# Altium schematic sheet 超级电容3.SchDoc
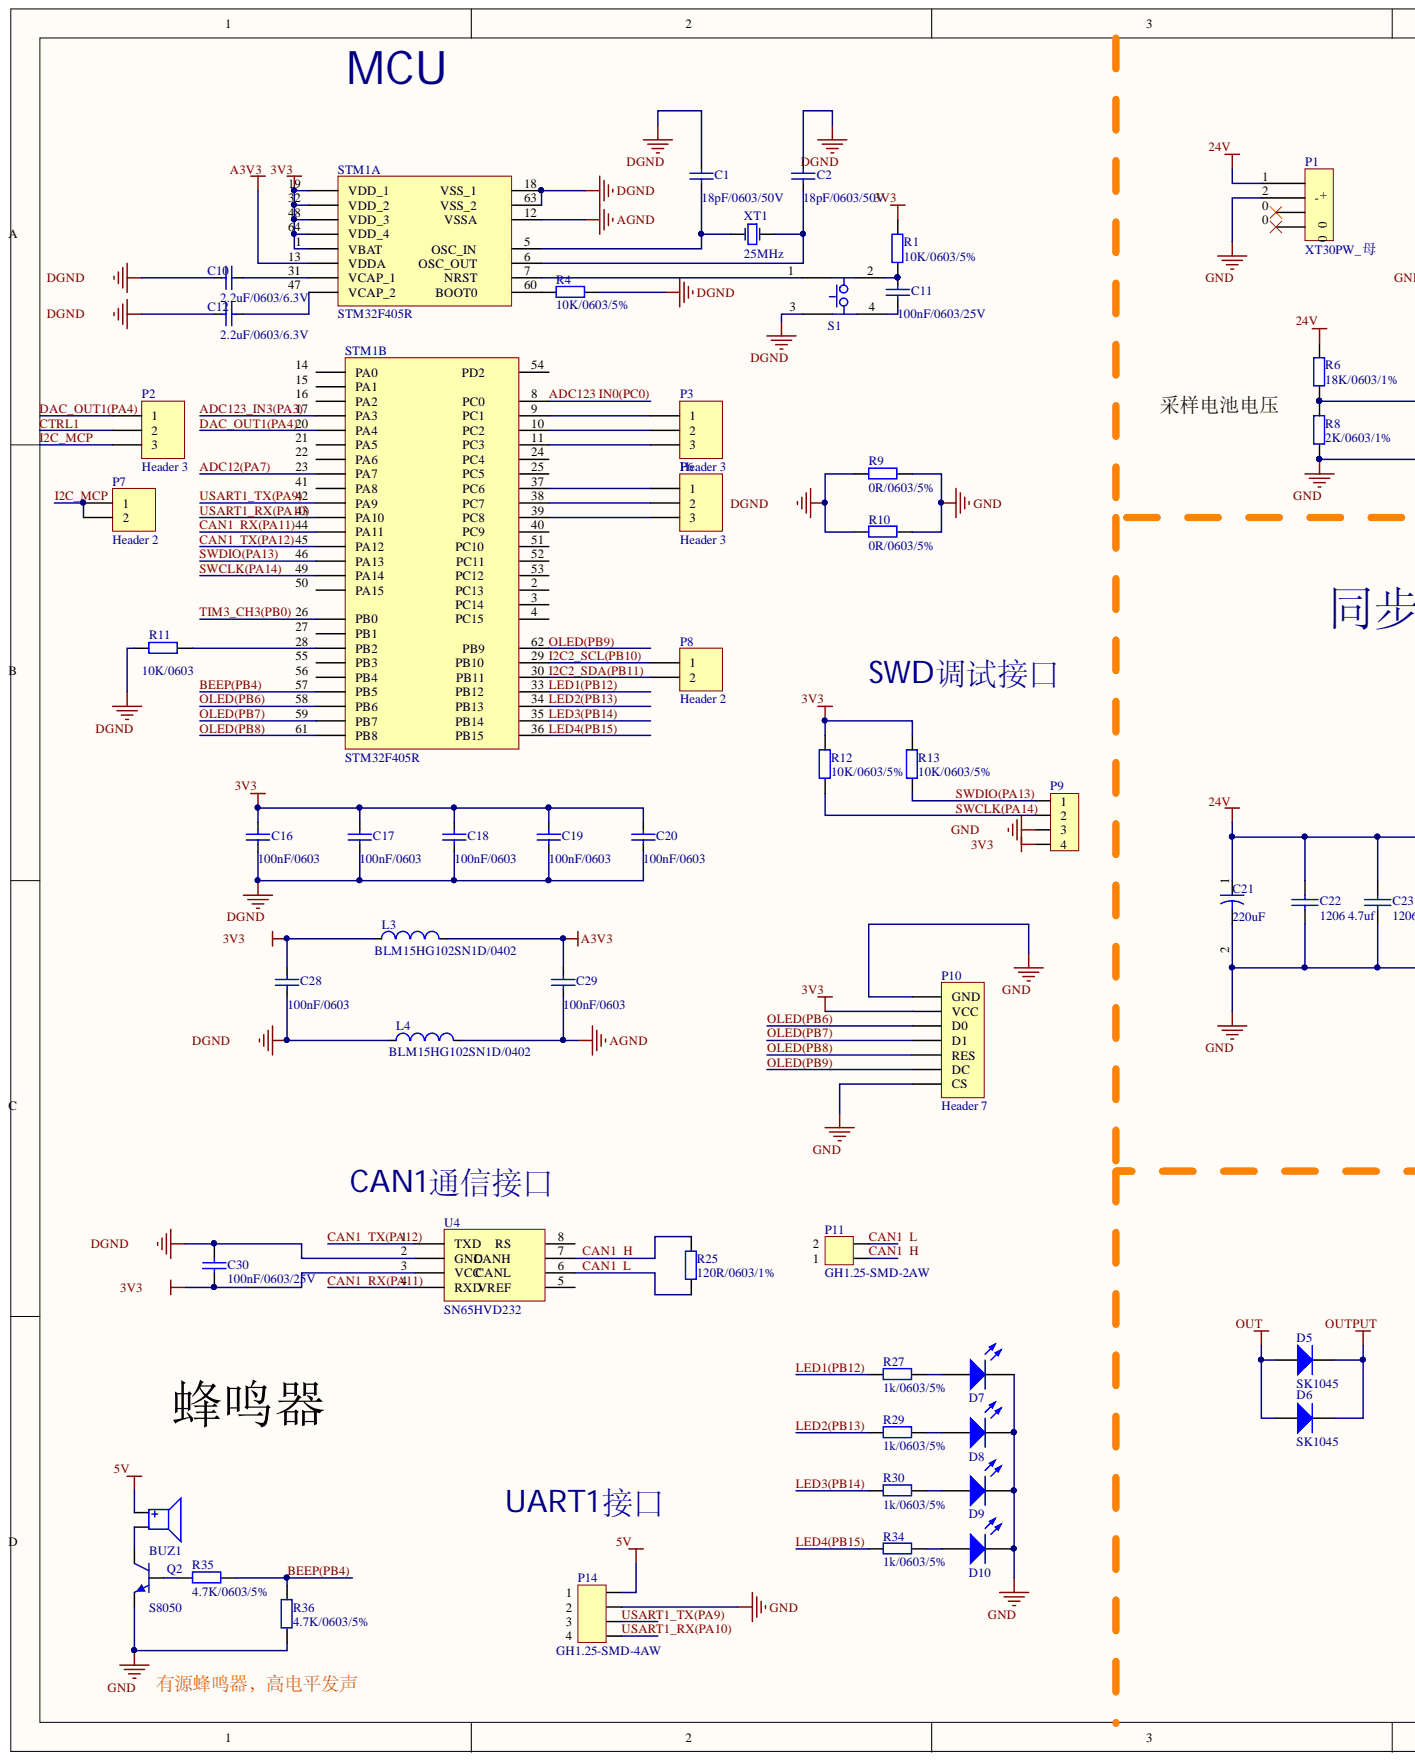
[diagram p0: 超级电容3.SchDoc, left]
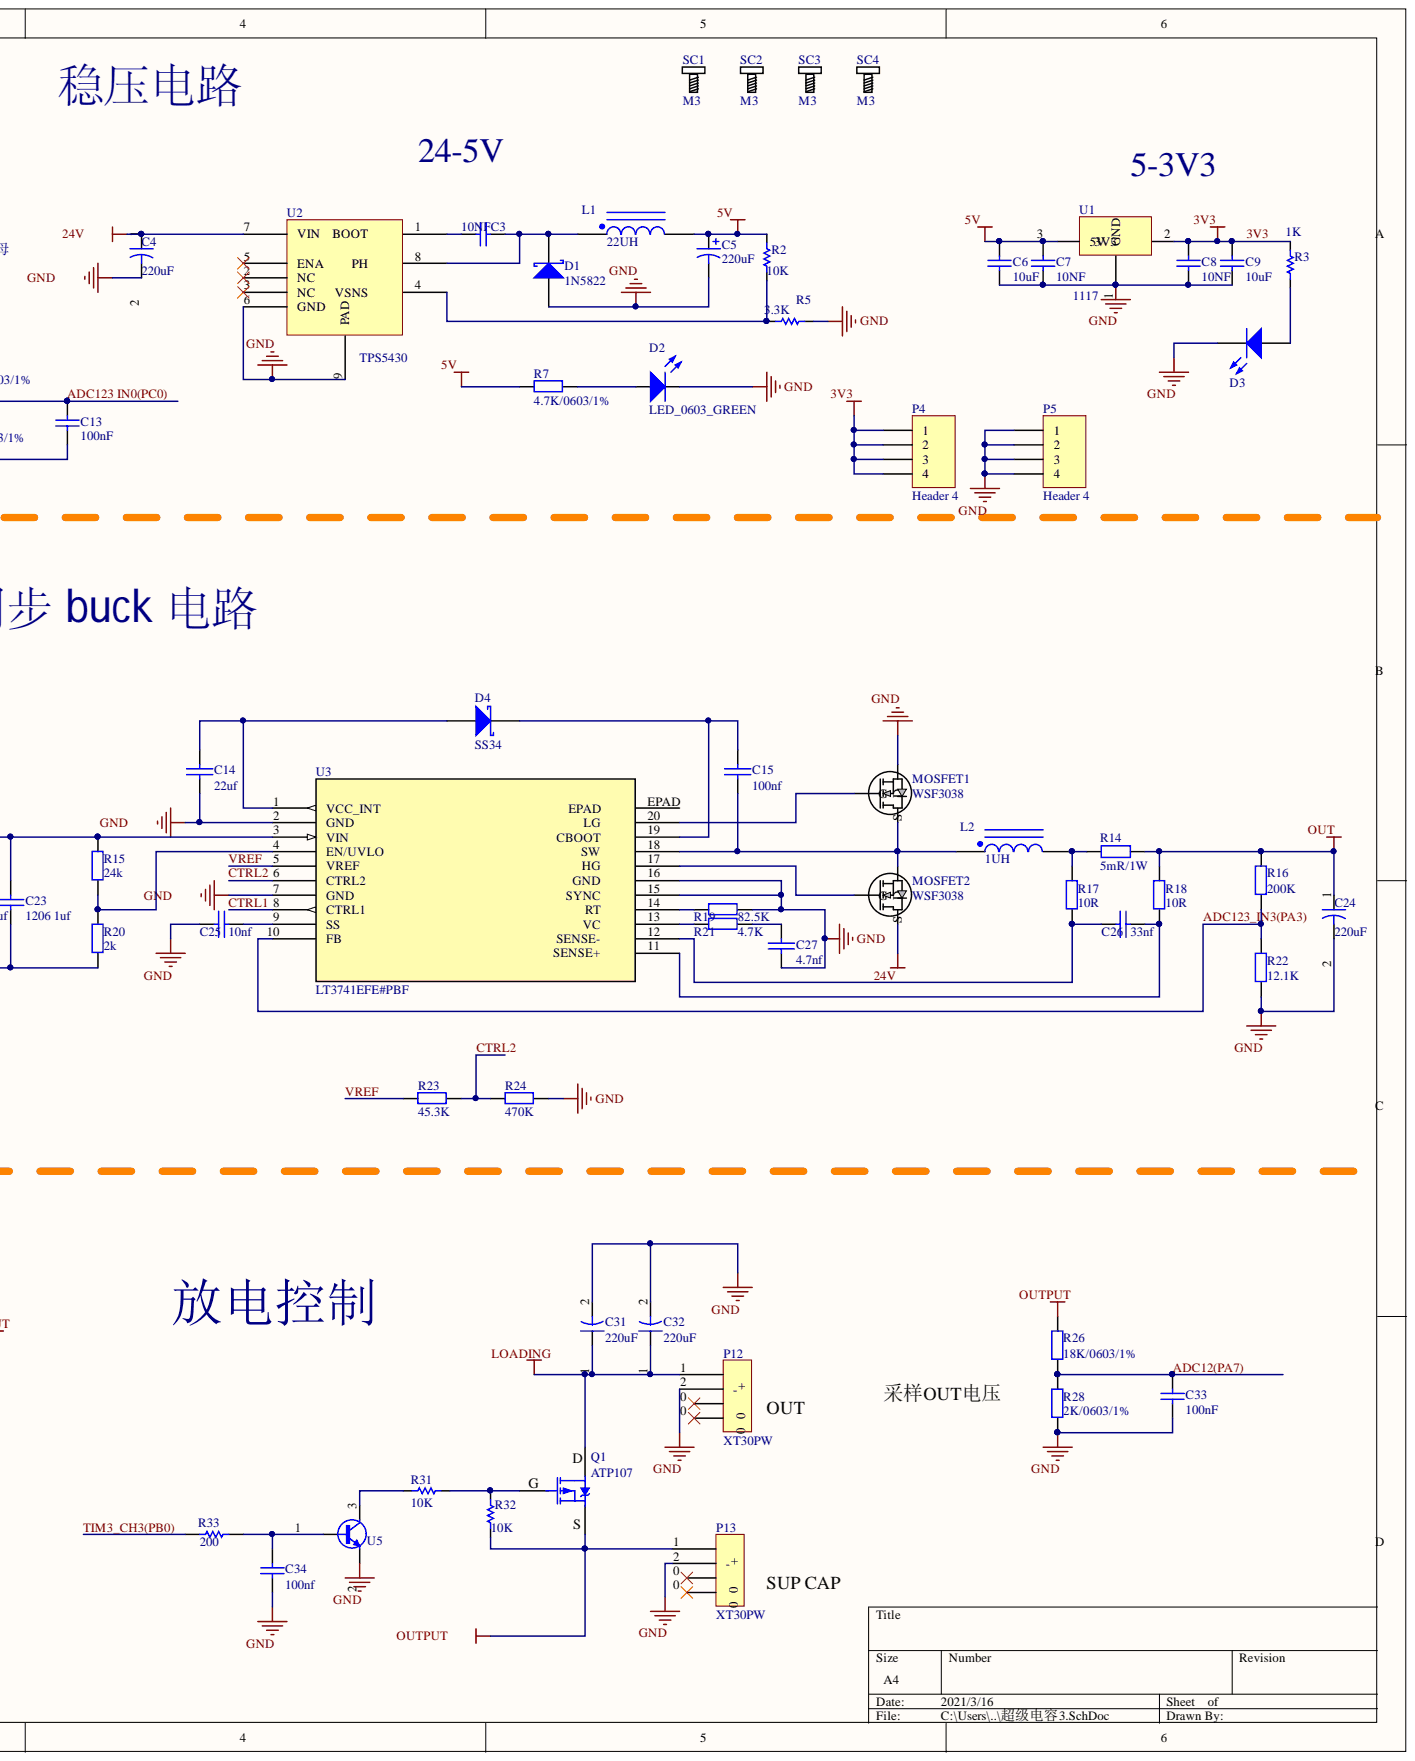
[diagram p1: 超级电容3.SchDoc, right]

## Parts
BUZ1  Buzzer  [蜂鸣器]  (value not drawn)
C1  value 18pF/0603/50V  [C-SMD-0603]  (hidden comment: 18PF)
C2  value 18pF/0603/50V  [C-SMD-0603]  (hidden comment: 18PF)
C3  10NF  [C-SMD-0603]
C4  220uF  [c8*12]
C5  220uF  [TCAP7343]
C6  10uF  [C-SMD-0603]
C7  10NF  [C-SMD-0603]
C8  10NF  [C-SMD-0603]
C9  10uF  [C-SMD-0603]
C10  value 2.2uF/0603/6.3V  [C-SMD-0603]  (hidden comment: 2.2UF)
C11  value 100nF/0603/25V  [C-SMD-0603]  (hidden comment: 100NF)
C12  value 2.2uF/0603/6.3V  [C-SMD-0603]  (hidden comment: 2.2UF)
C13  100NF  [C-SMD-0603]
C14  22uf  [C-SMD-0603]
C15  100nf  [C-SMD-0603]
C16  100nF/0603  [C-SMD-0603]
C17  100nF/0603  [C-SMD-0603]
C18  100nF/0603  [C-SMD-0603]
C19  100nF/0603  [C-SMD-0603]
C20  100nF/0603  [C-SMD-0603]
C21  220uF  [c8*12]
C22  1206 4.7uf  [C 1206]
C23  1206 1uf  [C 1206]
C24  220uF  [c8*12]
C25  10nf  [C-SMD-0603]
C26  33nf  [C-SMD-0603]
C27  4.7nf  [C-SMD-0603]
C28  100nF/0603  [C-SMD-0603]
C29  100nF/0603  [C-SMD-0603]
C30  value 100nF/0603/25V  [C-SMD-0603]  (hidden comment: 100NF)
C31  220uF  [c8*12]
C32  220uF  [c8*12]
C33  100NF  [C-SMD-0603]
C34  100nf  [C-SMD-0603]
D1  1N5822  [DO-214AC_SS14]
D2  LED_0603_GREEN  [LED_0603_GREEN]
D3  LED0  [LED_0603_GREEN]  (value not drawn)
D4  SS34  [DO-214AC_SS14]
D5  SK1045  [SMC]
D6  SK1045  [SMC]
D7  LED  [LED-0603]  (value not drawn)
D8  LED  [LED-0603]  (value not drawn)
D9  LED  [LED-0603]  (value not drawn)
D10  LED  [LED-0603]  (value not drawn)
L1  22UH  [0630_INDUCTANCE]
L2  1UH  [CDRH124]
L3  BLM15HG102SN1D/0402  [l-SMD-0402]
L4  BLM15HG102SN1D/0402  [l-SMD-0402]
MOSFET1  WSF3038  [TO252]
MOSFET2  WSF3038  [TO252]
P1  XT30PW_母  [XT30PW-M]
P2  Header 3  [HDR1X3]
P3  Header 3  [HDR1X3]
P4  Header 4  [HDR1X4]
P5  Header 4  [HDR1X4]
P6  Header 3  [HDR1X3]
P7  Header 2  [HDR1X2]
P8  Header 2  [HDR1X2]
P9  GH1.25-SMD-4AW  [MOLEX1.25-4P]
P10  Header 7  [HDR1X7]
P11  GH1.25-SMD-2AW  [GH1.25-SMD-2AW]
P12  XT30PW  [XT30PW-F]
P13  XT30PW  [XT30PW-M]
P14  GH1.25-SMD-4AW  [GH1.25-SMD-4AW]
Q1  ATP107  [TO252（ATP07）]
Q2  S8050  [SOT23-3]
R1  10K  value 10K/0603/5%  [R-SMD-0603]
R2  10K  [R-SMD-0603]
R3  1K  [R-SMD-0603]
R4  10K  value 10K/0603/5%  [R-SMD-0603]
R5  3.3K  [R-SMD-0603]
R6  18K  value 18K/0603/1%  [R-SMD-0603]
R7  value 4.7K/0603/1%  [R-SMD-0603]  (hidden comment: 4.7k)
R8  2K  value 2K/0603/1%  [R-SMD-0603]
R9  value 0R/0603/5%  [R-SMD-0603]  (hidden comment: Res_0603)
R10  value 0R/0603/5%  [R-SMD-0603]  (hidden comment: Res_0603)
R11  10K/0603  [R-SMD-0603]
R12  10K  value 10K/0603/5%  [R-SMD-0603]
R13  10K  value 10K/0603/5%  [R-SMD-0603]
R14  5mR/1W  [R 1206-HP]
R15  value 24k  [R-SMD-0603]  (hidden comment: 10K)
R16  value 200K  [R-SMD-0603]  (hidden comment: 10K)
R17  value 10R  [R-SMD-0603]  (hidden comment: 10K)
R18  value 10R  [R-SMD-0603]  (hidden comment: 10K)
R19  value 82.5K  [R-SMD-0603]  (hidden comment: 10K)
R20  value 2k  [R-SMD-0603]  (hidden comment: 10K)
R21  value 4.7K  [R-SMD-0603]  (hidden comment: 10K)
R22  value 12.1K  [R-SMD-0603]  (hidden comment: 10K)
R23  value 45.3K  [R-SMD-0603]  (hidden comment: 10K)
R24  value 470K  [R-SMD-0603]  (hidden comment: 10K)
R25  120R  value 120R/0603/1%  [R-SMD-0603]
R26  18K  value 18K/0603/1%  [R-SMD-0603]
R27  1k  value 1k/0603/5%  [R-SMD-0603]
R28  2K  value 2K/0603/1%  [R-SMD-0603]
R29  1k  value 1k/0603/5%  [R-SMD-0603]
R30  1k  value 1k/0603/5%  [R-SMD-0603]
R31  10K  [R-SMD-0603]
R32  10K  [R-SMD-0603]
R33  value 200  [R-SMD-0603]  (hidden comment: 10k)
R34  1k  value 1k/0603/5%  [R-SMD-0603]
R35  value 4.7K/0603/5%  [R-SMD-0603]  (hidden comment: Res_0402)
R36  value 4.7K/0603/5%  [R-SMD-0603]  (hidden comment: Res_0402)
S1  SKRPADE010  [SKRPADE010]  (value not drawn)
SC1  M3  [SCRREW_M3]
SC2  M3  [SCRREW_M3]
SC3  M3  [SCRREW_M3]
SC4  M3  [SCRREW_M3]
STM1  STM32F405R  [LQFP64]  (parts A,B)
U1  1117  [SOT223_1117__]
U2  TPS5430  [SOIC-1.7mm_TPS5430__]
U3  LT3741EFE#PBF  [TSSOP-20_FE/CB_LIT-M]
U4  SN65HVD232  [SOP-8]
U5  SS8050  [SOT23-3]  (value not drawn)
XT1  value 25MHz  [XTAL3225]  (hidden comment: XTAL_5032)

## Nets
5V: BUZ1.1 C5.1 C6.2 C7.2 L1.2 P14.1 R2.1 R7.1 U1.3
24V: C4.1 C21.1 C22.1 C23.1 MOSFET2.2 P1.1 R6.2 R15.1 U2.7(VIN) U3.3(VIN)
A3V3: C29.2 L3.2 STM1.13(VDDA)
AGND: C29.1 L4.2 STM1.12
DGND: C1.2 C2.2 C10.2 C12.2 C16.1 C17.1 C18.1 C19.1 C20.1 C28.1 C30.2 L4.1 R4.1 R9.1 R10.1 R11.1 S1.3 STM1.18 STM1.63 U4.2(GND)
GND: C4.2 C5.2 C6.1 C7.1 C8.1 C9.1 C13.1 C14.1 C21.2 C22.2 C23.2 C24.2 C25.1 C27.2 C31.2 C32.2 C33.1 C34.1 D1.1 D2.2 D3.2 D7.2 D8.2 D9.2 D10.2 MOSFET1.3 P1.2(-) P5.1 P5.2 P5.3 P5.4 P9.3 P10.1(GND) P10.7(CS) P12.2(-) P13.2(-) P14.2 Q2.2 R5.1 R8.1 R9.2 R10.2 R19.2 R20.2 R22.2 R24.2 R28.1 R36.1 U1.1 U2.6(GND) U2.9(PAD) U3.2(GND) U3.7(GND) U3.15 U3.16 U5.2
LOADING: C31.1 C32.1 P12.1 Q1.2
OUT: C24.1 D5.1 D6.1 R14.2 R16.1 R18.1
OUTPUT: D5.2 D6.2 P13.1 Q1.3 R26.2 R32.2
3V3: C8.2 C9.2 C16.2 C17.2 C18.2 C19.2 C20.2 C28.2 C30.1 L3.1 P4.1 P4.2 P4.3 P4.4 P9.4 P10.2(VCC) R1.2 R3.1 R12.2 R13.1 STM1.1(VBAT) STM1.19(VDD_1) STM1.32(VDD_2) STM1.48(VDD_3) STM1.64(VDD_4) U1.2 U4.3(VCC)
ADC12(PA7): C33.2 R26.1 R28.2 STM1.23(PA7)
ADC123 IN0(PC0): C13.2 R6.1 R8.2 STM1.8
ADC123_IN3(PA3): R16.2 R22.1 STM1.17(PA3) U3.10(FB)
BEEP(PB4): R35.2 R36.2 STM1.57(PB5)
CAN1  H: P11.1 R25.2 U4.7
CAN1  L: P11.2 R25.1 U4.6
CAN1  RX(PA11): STM1.44(PA11) U4.4(RXD)
CAN1  TX(PA12): STM1.45(PA12) U4.1(TXD)
CTRL1: P2.2 U3.8(CTRL1)
CTRL2: R23.2 R24.1 U3.6(CTRL2)
DAC_OUT1(PA4): P2.1 STM1.20(PA4)
I2C2_SCL(PB10): P8.1 STM1.29
I2C2_SDA(PB11): P8.2 STM1.30
I2C_MCP: P2.3 P7.1 P7.2
LED1(PB12): R27.1 STM1.33
LED2(PB13): R29.1 STM1.34
LED3(PB14): R30.1 STM1.35
LED4(PB15): R34.1 STM1.36
OLED(PB6): P10.3(D0) STM1.58(PB6)
OLED(PB7): P10.4(D1) STM1.59(PB7)
OLED(PB8): P10.5(RES) STM1.61(PB8)
OLED(PB9): P10.6(DC) STM1.62
SWCLK(PA14): P9.2 R12.1 STM1.49(PA14)
SWDIO(PA13): P9.1 R13.2 STM1.46(PA13)
TIM3_CH3(PB0): R33.1 STM1.26(PB0)
USART1_RX(PA10): P14.4 STM1.43(PA10)
USART1_TX(PA9): P14.3 STM1.42(PA9)
VREF: R23.1 U3.5(VREF)
NetBUZ1_2: BUZ1.2 Q2.3
NetC1_1: C1.1 STM1.5 XT1.1
NetC2_1: C2.1 STM1.6 XT1.3
NetC3_1: C3.1 U2.1
NetC3_2: C3.2 D1.2 L1.1 U2.8
NetC10_1: C10.1 STM1.31(VCAP_1)
NetC11_1: C11.1 S1.4
NetC11_2: C11.2 R1.1 S1.2
NetC12_1: C12.1 STM1.47(VCAP_2)
NetC14_2: C14.2 D4.1 U3.1(VCC_INT)
NetC15_1: C15.1 L2.1 MOSFET1.2 MOSFET2.3 U3.18
NetC15_2: C15.2 D4.2 U3.19
NetC25_2: C25.2 U3.9(SS)
NetC26_1: C26.1 R17.2 U3.12
NetC26_2: C26.2 R18.2 U3.11
NetC27_1: C27.1 R21.2
NetC34_2: C34.2 R33.2 U5.1
NetD2_1: D2.1 R7.2
NetD3_1: D3.1 R3.2
NetD7_1: D7.1 R27.2
NetD8_1: D8.1 R29.2
NetD9_1: D9.1 R30.2
NetD10_1: D10.1 R34.2
NetL2_2: L2.2 R14.1 R17.1
NetMOSFET1_1: MOSFET1.1 U3.20
NetMOSFET2_1: MOSFET2.1 U3.17
NetP3_1: P3.1 STM1.9
NetP3_2: P3.2 STM1.10
NetP3_3: P3.3 STM1.11
NetP6_1: P6.1 STM1.37
NetP6_2: P6.2 STM1.38
NetP6_3: P6.3 STM1.39
NetQ1_1: Q1.1 R31.2 R32.1
NetQ2_1: Q2.1 R35.1
NetR2_2: R2.2 R5.2 U2.4
NetR4_2: R4.2 STM1.60
NetR11_2: R11.2 STM1.28(PB2)
NetR15_2: R15.2 R20.1 U3.4(EN/UVLO)
NetR19_1: R19.1 U3.14
NetR21_1: R21.1 U3.13
NetR31_1: R31.1 U5.3
NetS1_1: S1.1 STM1.7

Not connected: P1 0; P12 0; P13 0; STM1 2 3 4 14(PA0) 15(PA1) 16(PA2) 21(PA5) 22(PA6) 24 25 27(PB1) 40 41(PA8) 50(PA15) 51 52 53 54 55(PB3) 56(PB4); U2 2(NC) 3(NC) 5(ENA); U3 EPAD; U4 5 8

## Library data of the parts
C1 C2 C10-C12 = Cap_0402: Capacitor; Component Kind: Standard; Library Name: SCH_Passive.SchLib
C3 C6-C9 C13 C33 C34 = Cap: 无极性电容; Component Kind: Standard; LatestRevisionDate: 17-Jul-2002; LatestRevisionNote: Re-released for DXP Platform.; PackageReference: RAD-0.3; Published: 8-Jun-2000; Publisher: Altium Limited
  C3 C6-C9 C34: Library Name: Devices.IntLib
  C13 C33: Value: 100nF; Library Name: Fszhang.IntLib
C4 C21 C24 C31 C32 = Cap2: Capacitor; Component Kind: Standard; Library Name: Miscellaneous Devices.IntLib; PackageDescription: Capacitor; 2 Leads; PackageReference: CAPR5-4X5; Published: 23-Sep-2002; Signal Integrity: Cap; Simulation: CAP; Publisher: Altium Limited
C5 = Cap Pol1: Polarized Capacitor (Radial); Component Kind: Standard; LatestRevisionDate: 17-Jul-2002; LatestRevisionNote: Re-released for DXP Platform.; Library Name: Miscellaneous Devices.IntLib; PackageDescription: Polarized Capacitor (Radial); 2 Leads; PackageReference: RB7.6-15; Published: 8-Jun-2000; Signal Integrity: Cap Pol; Simulation: CAP; Value: 220uF; Publisher: Altium Limited
C14 C15 C22 C23 C25-C27 = CAP: Component Kind: Standard; Library Name: Common Device.IntLib
C16-C20 C28 C29 = Cap_0402: Capacitor; Value: 100nF/0603/25V; Component Kind: Standard; Library Name: SCH_Passive.SchLib
C30 = Cap: 贴片电容; Component Kind: Standard; LatestRevisionDate: 17-Jul-2002; LatestRevisionNote: Re-released for DXP Platform.; PackageDescription: Radial Cap, Thru-Hole; 2 Leads; 0.3 in Pin Spacing; PackageReference: RAD-0.3; Published: 8-Jun-2000; Library Name: 三代主控板原理图库.SCHLIB; Publisher: Altium Limited
D1 D4 = D Schottky: Schottky Diode; Code_JEDEC: DO-214-AA; Component Kind: Standard; LatestRevisionDate: 17-Jul-2002; LatestRevisionNote: Re-released for DXP Platform.; Library Name: Miscellaneous Devices.IntLib; PackageDescription: DO-214-AA/SMB; 2 C-Bend Leads; Body 5.3 x 3.6 mm, inc. leads (LxW); PackageReference: SMB; Published: 8-Jun-2000; Signal Integrity: D Schottky; Simulation: SKYDIODE; Publisher: Altium Limited
D2 = LED_0603_GREEN: LED; Component Kind: Standard; Library Name: 底盘主控.IntLib
D3 D7-D10 = LED0: 发光二极管; Component Kind: Standard; LatestRevisionDate: 08-Jul-2005; LatestRevisionNote: Mech Layer 1 removed.; Library Name: Devices.IntLib; PackageReference: LED-0; Published: 8-Jun-2000; Publisher: Altium Limited
D5 D6 = Diode: Component Kind: Standard; Library Name: Common Device.IntLib
L1 L2 = Inductor Iron Dot: Magnetic-Core Inductor with Winding Polarity Marking; Component Kind: Standard; LatestRevisionDate: 17-Jul-2002; LatestRevisionNote: Re-released for DXP Platform.; Library Name: Miscellaneous Devices.IntLib; PackageDescription: Diode; 2 Leads; PackageReference: DIODE_SMC; Published: 24-Mar-1999; Publisher: Altium Limited
  L1: Signal Integrity: Inductor Iron Dot
L3 L4 = Ind_0402: BLM15HG102SN1D; Component Kind: Standard; Value: BLM15HG102SN1D/0402; Library Name: 电容电阻电感.SchLib
MOSFET1 MOSFET2 = SUD40N10-25-E3: No Description Available; Built_By: EMA_Cory; SourceLibrary: Vishay_2020-08-31; Vendor: Vishay; Component Kind: Standard; Datasheet: https://www.vishay.com/docs/71140/sud40n10.pdf; Library Name: MOSFET.SchLib; Manufacturer_Part_Number: SUD40N10-25-E3; RefDes: RefDes; Type: DEV; Copyright: Copyright (C) 2018 Accelerated Designs. All rights reserved
P1 P12 P13 = Component_1: Component Kind: Standard; Library Name: Schlib1.SchLib
P2 P3 P6 = Header 3: Header, 3-Pin; Component Kind: Standard; LatestRevisionDate: 17-Jul-2002; LatestRevisionNote: Re-released for DXP Platform.; Library Name: Miscellaneous Connectors.IntLib; Signal Integrity: Connector; Publisher: Altium Limited
P4 P5 = Header 4: Header, 4-Pin; Component Kind: Standard; LatestRevisionDate: 17-Jul-2002; LatestRevisionNote: Re-released for DXP Platform.; Library Name: Miscellaneous Connectors.IntLib; Signal Integrity: Connector; Publisher: Altium Limited
P7 P8 = Header 2: Header, 2-Pin; Component Kind: Standard; LatestRevisionDate: 17-Jul-2002; LatestRevisionNote: Re-released for DXP Platform.; Library Name: Miscellaneous Connectors.IntLib; Signal Integrity: Connector; Publisher: Altium Limited
P9 P14 = GH1.25-SMD-4AW: pitch 1.25mm，4P，公座（A代表公，Y代表母），卧贴; Component Kind: Standard; Library Name: SCH_Connector.SchLib
P10 = Header 7: Header, 7-Pin; Component Kind: Standard; LatestRevisionDate: 17-Jul-2002; LatestRevisionNote: Re-released for DXP Platform.; Library Name: Miscellaneous Connectors.IntLib; Signal Integrity: Connector; Publisher: Altium Limited
P11 = GH1.25-SMD-2AW: pitch 1.25mm，2P，公座（A代表公，Y代表母），卧贴; Component Kind: Standard; Library Name: SCH_Connector.SchLib
Q1 = ATP107: P沟道场效应管; 版权归属: 源创客; BOM名称: P沟道场效应管; Component Kind: Standard; 学习资源: www.ooocke.com; Library Name: 超级电容原理图库.SchLib
Q2 = BJT_NPN: Transistor; Component Kind: Standard; Library Name: SCH_Active.SchLib; value: S8050
R1 R4 R12 R13 R15-R24 R35 R36 = Res_0402: Resistor; Component Kind: Standard; Library Name: SCH_Passive.SchLib
R2 R3 R5-R8 R26 R28 R31 R32 = Res1: 贴片电阻; Component Kind: Standard; LatestRevisionDate: 17-Jul-2002; LatestRevisionNote: Re-released for DXP Platform.; PackageReference: AXIAL-0.4; Published: 8-Jun-2000; Publisher: Altium Limited
  R2 R31 R32: Library Name: Devices.IntLib; Value: 10K
  R3 R5: Library Name: Devices.IntLib
  R6 R8 R26 R28: Library Name: Fszhang.IntLib
  R7: Library Name: 底盘主控.IntLib
R9 R10 R27 R29 R30 R34 = Res_0603: Resistor; Component Kind: Standard; Library Name: 电容电阻电感.SchLib
R11 = Res_0402: Resistor; Value: 10K/0603/5%; Component Kind: Standard; Library Name: SCH_Passive.SchLib
R14 = R: 贴片电阻; 版权归属: 源创客; BOM名称: 贴片电阻; Component Kind: Standard; Library Name: 电阻-电容-电感.SchLib; 学习资源: www.ooocke.com
R25 = Res: 贴片电阻; Component Kind: Standard; Library Name: 三代主控板原理图库.SCHLIB
R33 = Res Semi: Semiconductor Resistor; Component Kind: Standard; LatestRevisionDate: 17-Jul-2002; LatestRevisionNote: Re-released for DXP Platform.; Library Name: Miscellaneous Devices.IntLib; PackageDescription: Axial Device, Thru-Hole; 2 Leads; 0.5 in Pin Spacing; PackageReference: AXIAL-0.5; Published: 8-Jun-2000; Signal Integrity: Res Semi; Simulation: RES; Publisher: Altium Limited
S1 = SKRPADE010: Switch; Component Kind: Standard; LatestRevisionDate: 17-Jul-2002; LatestRevisionNote: Re-released for DXP Platform.; Library Name: SCH_Switch.SchLib; PackageReference: DIP-4; Published: 24-Mar-1999; Publisher: Altium Limited
SC1-SC4 = Screw_M3: Component Kind: Standard; Library Name: 2017-7-21.SchLib
STM1 = STM32F405R: 整合版; Component Kind: Standard; Library Name: 芯片.SchLib
U1 = AMS1117-3.3: Component Kind: Standard; Library Name: SHAWN.IntLib
U3 = LT3741EFE#PBF: No Description Available; Built_By: EMA_UL_Team; SourceLibrary: LinearTech_2016-05-13_4; Vendor: Linear Technology; Component Kind: Standard; Library Name: LT3741.SchLib; Manufacturer_Part_Number: LT3741EFE#PBF; RefDes: RefDes; Type: DEV; Copyright: Copyright (C) 2018 Accelerated Designs. All rights reserved
U4 = SN65HVD232: CAN; Component Kind: Standard; Library Name: SCH_IC.SchLib
U5 = BJT-2N5551: Component Kind: Standard; Library Name: SHAWN.IntLib
XT1 = XTAL_5032: Crystal Oscillator; Component Kind: Standard; LatestRevisionDate: 17-Jul-2002; LatestRevisionNote: Re-released for DXP Platform.; Library Name: SCH_Oscillator.SchLib; PackageDescription: Crystal, Thru-Hole; 2 Leads; Body 3.1 x 8.2 mm (Dia.xH); PackageReference: R38; Published: 8-Jun-2000; Publisher: Altium Limited
BUZ1 = Buzzer: Component Kind: Standard; Description: Buzzer; Library Name: SCH_Module.SchLib
U2 = TPS5430: Component Kind: Standard; Library Name: Schlib1.SchLib
